# Altium schematic sheet iF11M.SchDoc
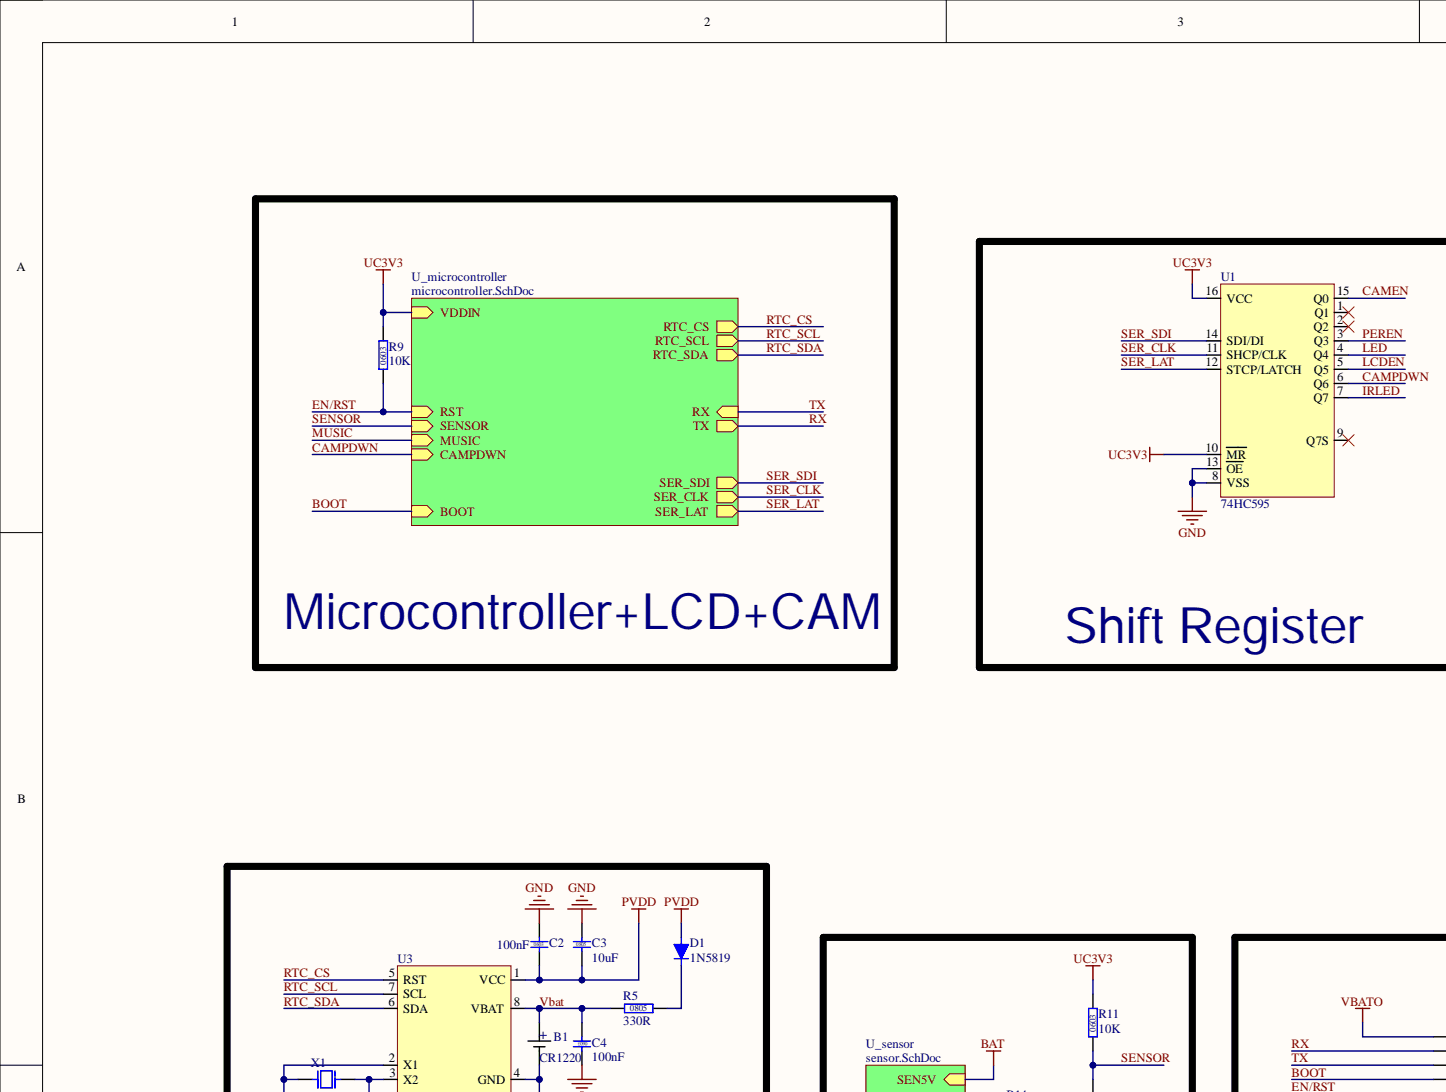
[diagram p0: iF11M.SchDoc, top left]
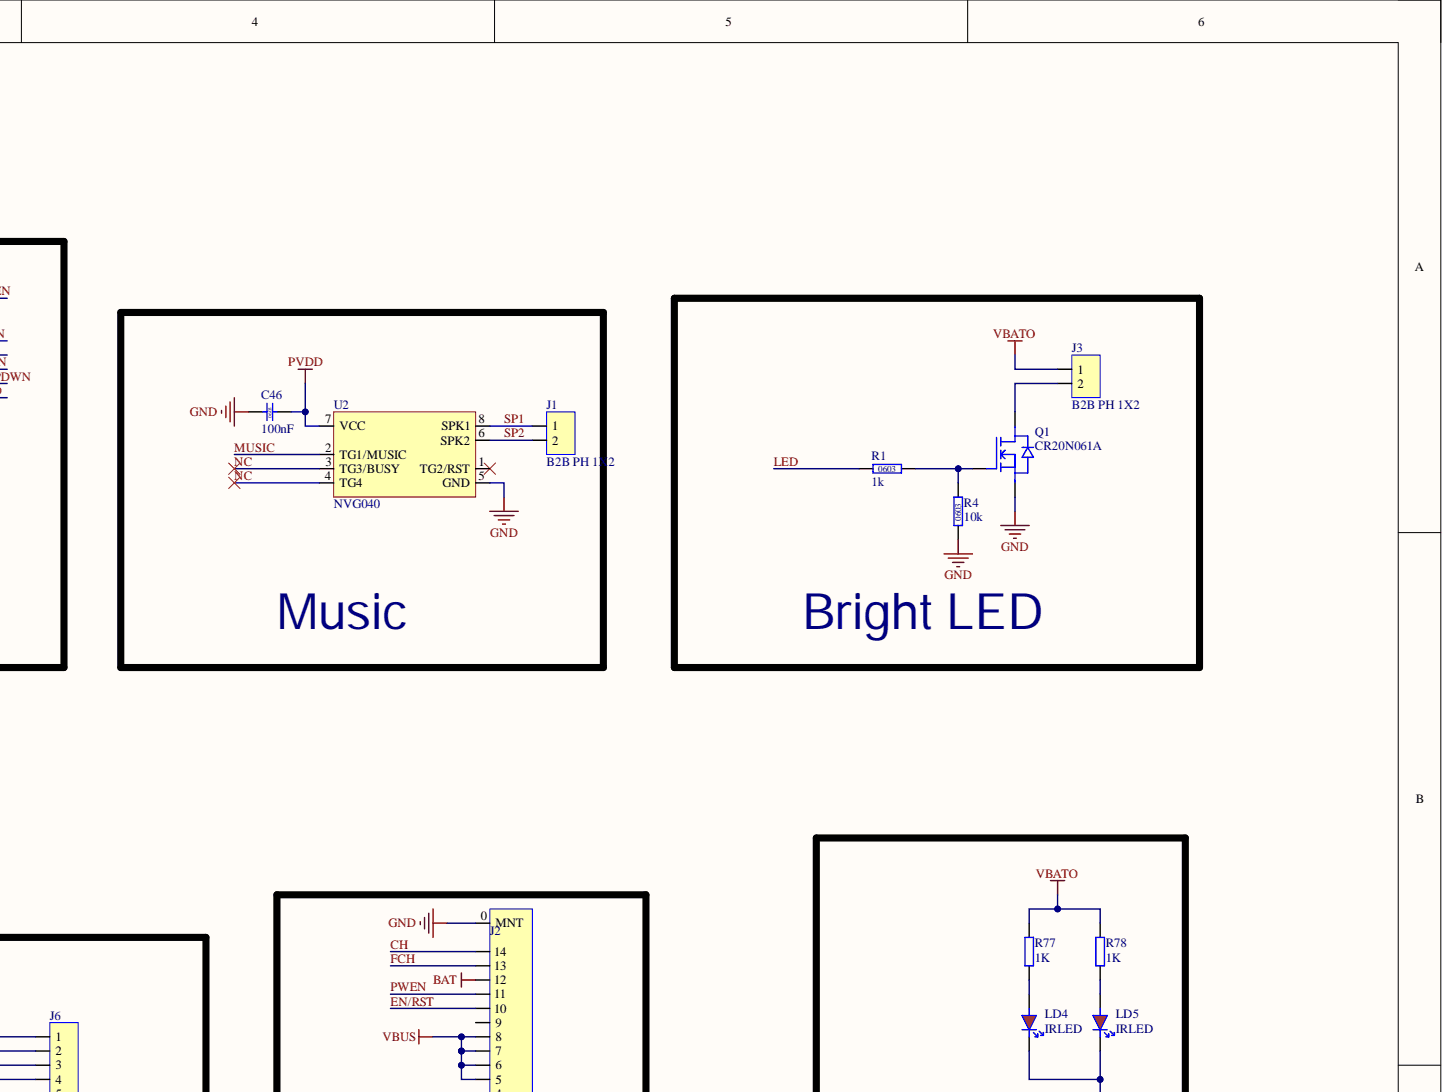
[diagram p1: iF11M.SchDoc, top right]
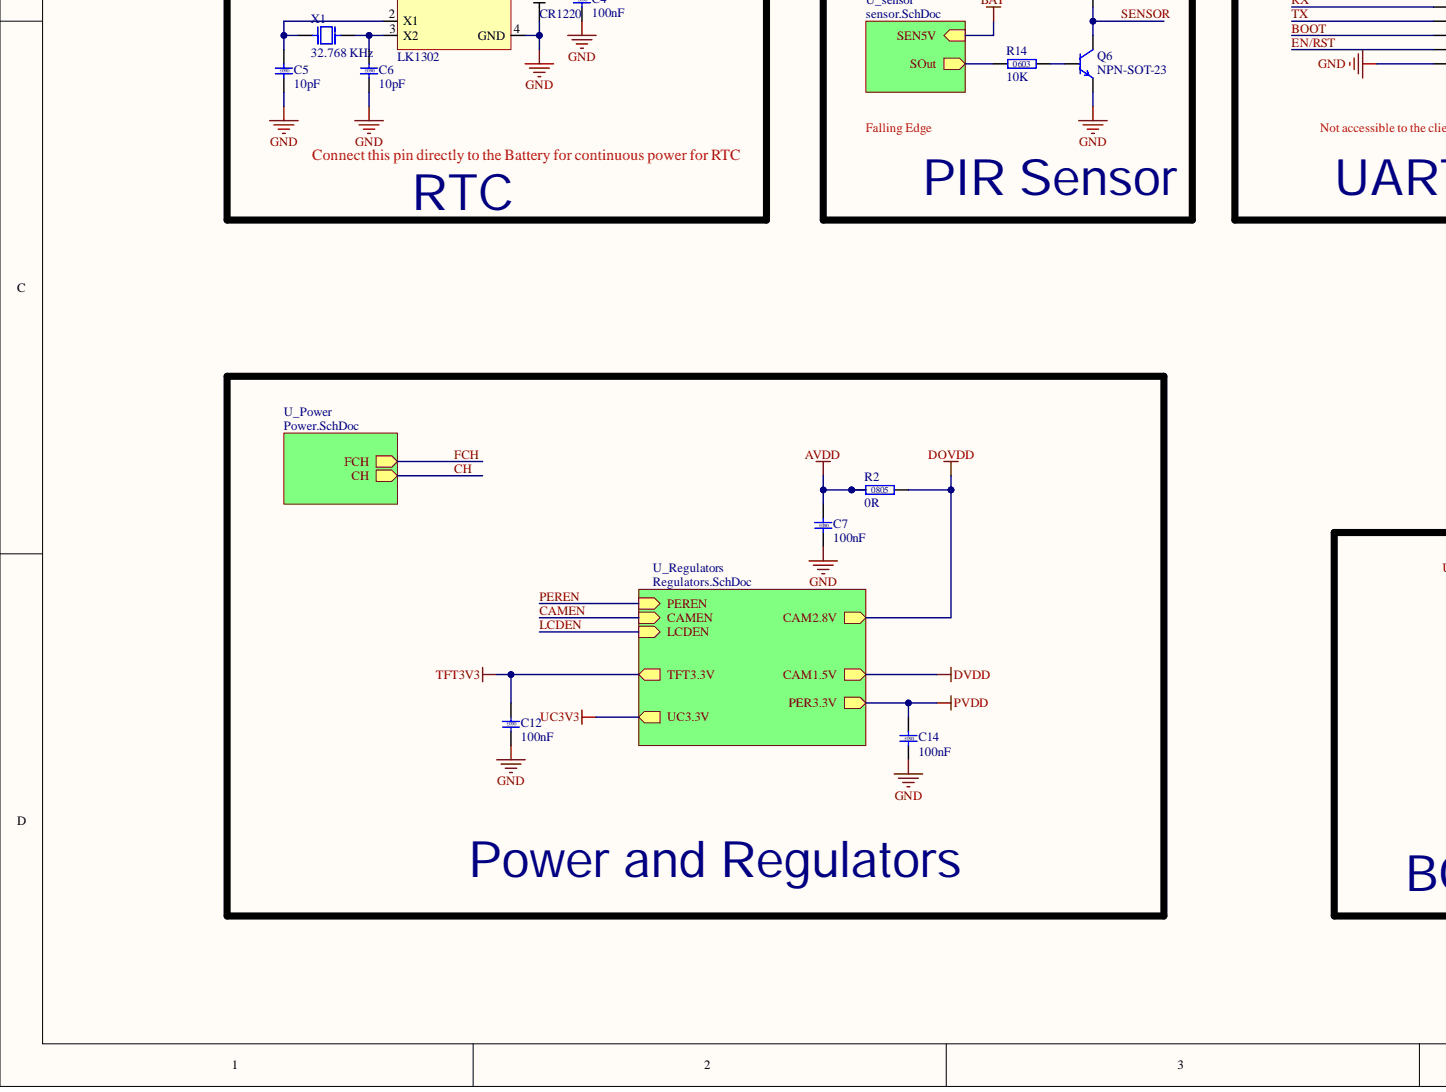
[diagram p2: iF11M.SchDoc, bottom left]
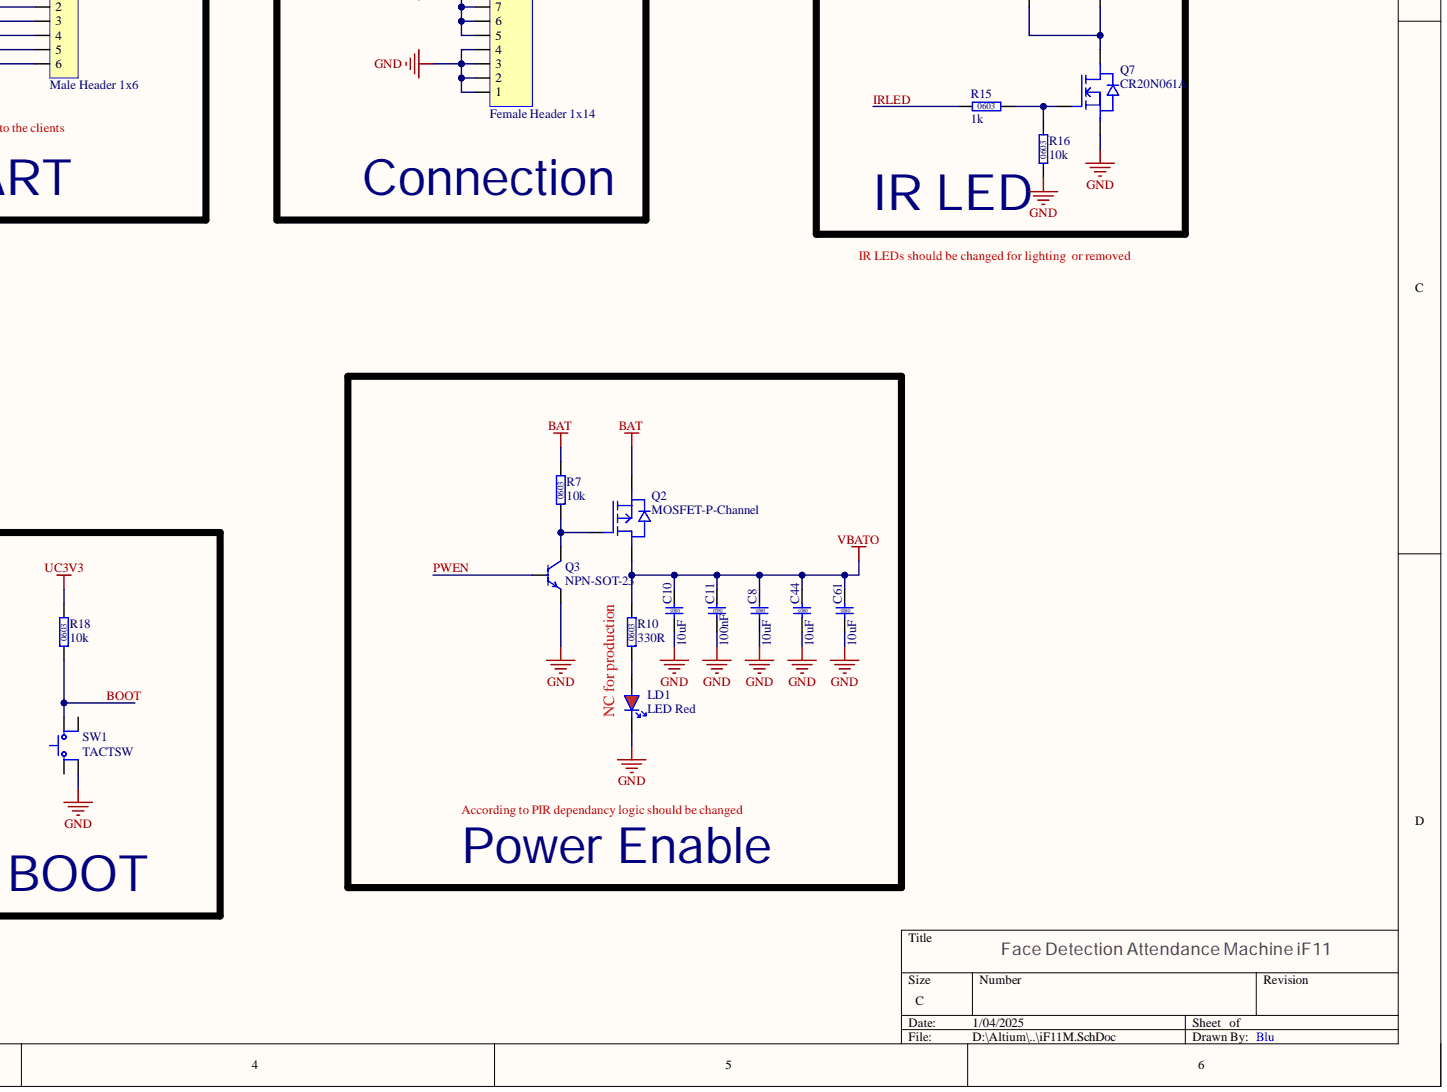
[diagram p3: iF11M.SchDoc, bottom right]

## Parts
B1  CR1220  [CR1220]
C2  100nF  [C0603]
C3  10uF  [C0805]
C4  100nF  [C0603]
C5  10pF  [C0603]
C6  10pF  [C0603]
C7  100nF  [C0603]
C8  10uF  [C0805]
C10  10uF  [C0805]
C11  100nF  [C0603]
C12  100nF  [C0603]
C14  100nF  [C0603]
C44  10uF  [C0805]
C46  100nF  [C0603]
C61  10uF  [C0805]
D1  1N5819  [SOD-323]
J1  B2B PH 1X2  [B2B-PH-2mm]
J2  Female Header 1x14  [FPC14-1mm-SMT]
J3  B2B PH 1X2  [B2B-PH-2mm]
J6  Male Header 1x6  [MHEADER-1x6]
LD1  LED Red  [LED-0603-RED]
LD4  IRLED  [LED 5mm RED Bent]
LD5  IRLED  [LED 5mm RED Bent]
Q1  CR20N061A  [NMOS-SOT23]
Q2  MOSFET-P-Channel  [PMOS-SOT23]
Q3  NPN-SOT-23  [SOT23]
Q6  NPN-SOT-23  [SOT23]
Q7  CR20N061A  [NMOS-SOT23]
R1  1k  [R0603-LD]
R2  0R  [R0805-LD]
R4  10k  [R0603-LD]
R5  330R  [R0805-LD]
R7  10k  [R0603-LD]
R9  10K  [R0603-LD]
R10  330R  [R0603-LD]
R11  10K  [R0603-LD]
R14  10K  [R0603-LD]
R15  1k  [R0603-LD]
R16  10k  [R0603-LD]
R18  10k  [R0603-LD]
R77  1K  [R0805]
R78  1K  [R0805]
SW1  TACTSW  [SMDtactswitch-5x5]
U1  74HC595  [SOIC16]
U2  NVG040  [SOP-8]
U3  LK1302  [SOP-8]
X1  32.768 KHz  [RTC Crystal]

## Nets
AVDD: C7.2 R2.1
BAT: J2.12 Q2.3 R7.2
DOVDD: R2.2
GND: B1.1 C2.1 C3.1 C4.1 C5.1 C6.1 C7.1 C8.1 C10.1 C11.1 C12.1 C14.1 C44.1 C46.1 C61.1 J2.0(MNT) J2.1 J2.2 J2.3 J2.4 J6.6 LD1.2 Q1.3 Q3.2 Q6.2 Q7.3 R4.1 R16.1 SW1.2 U1.8(VSS) U1.13(OE) U2.5(GND) U3.4(GND)
PVDD: C2.2 C3.2 C14.2 C46.2 D1.1 U2.7(VCC) U3.1(VCC)
TFT3V3: C12.2
UC3V3: R9.2 R11.2 R18.2 U1.10(MR) U1.16(VCC)
VBATO: C8.2 C10.2 C11.2 C44.2 C61.2 J3.1 J6.1 Q2.2 R10.2 R77.2 R78.2
VBUS: J2.5 J2.6 J2.7 J2.8
BOOT: J6.4 R18.1 SW1.4
CAMEN: U1.15(Q0)
CAMPDWN: U1.6(Q6)
CH: J2.14
EN/RST: J2.10 J6.5 R9.1
FCH: J2.13
IRLED: R15.1 U1.7(Q7)
LCDEN: U1.5(Q5)
LED: R1.1 U1.4(Q4)
MUSIC: U2.2(TG1/MUSIC)
NC: U2.3(TG3/BUSY) U2.4(TG4)
PEREN: U1.3(Q3)
PWEN: J2.11 Q3.1
RTC_CS: U3.5(RST)
RTC_SCL: U3.7(SCL)
RTC_SDA: U3.6(SDA)
RX: J6.2
SENSOR: Q6.3 R11.1
SER_CLK: U1.11(SHCP/CLK)
SER_LAT: U1.12(STCP/LATCH)
SER_SDI: U1.14(SDI/DI)
SP1: J1.1 U2.8(SPK1)
SP2: J1.2 U2.6(SPK2)
TX: J6.3
Vbat: B1.2 C4.2 R5.1 U3.8(VBAT)
NetC5_2: C5.2 U3.2(X1) X1.2
NetC6_2: C6.2 U3.3(X2) X1.1
NetD1_2: D1.2 R5.2
NetJ2_9: J2.9
NetJ3_2: J3.2 Q1.2
NetLD1_1: LD1.1 R10.1
NetLD4_1: LD4.1 R77.1
NetLD4_2: LD4.2 LD5.2 Q7.2
NetLD5_1: LD5.1 R78.1
NetQ1_1: Q1.1 R1.2 R4.2
NetQ2_1: Q2.1 Q3.3 R7.1
NetQ6_1: Q6.1 R14.2
NetQ7_1: Q7.1 R15.2 R16.2
NetR14_1: R14.1
NetSW1_1: SW1.1
NetSW1_3: SW1.3
NetU1_1: U1.1(Q1)
NetU1_2: U1.2(Q2)
NetU1_9: U1.9(Q7S)
NetU2_1: U2.1(TG2/RST)
DVDD:

## Library data of the parts
C2 C4 C7 C11 C12 C14 C46 = C 0603 100nF 50V: 10% 50V; Manufacturer: FENGHUA; Part No: W-T-CA-X703N100K50VNT; Material Name: 贴片电容0603 100NF/50V 10%; Product Code: WP0002721; Component Kind: Standard; Supplier: 南京南山半导体有限公司; Library Name: Rescap.IntLib
C3 C8 C10 C44 C61 = C 0805 10uF 25V: 10% 25V; Part No: WP0005368; Material Name: 贴片电容0805 10UF/25V 10%; Component Kind: Standard; Product Code: WP0005368; Supplier: 深圳市四叶电子有限公司; Library Name: Rescap.IntLib
C5 C6 = C 0603 10pF 25V: 5% 25V; Part No: W-T-CA-CG03P010J25VNT; Material Name: 贴片电容0603 10PF/25V 5%; Product Code: WP0002897; Component Kind: Standard; Supplier: 南京南山半导体有限公司; Library Name: Rescap.IntLib
D1 = IN4148: Component Kind: Standard; Library Name: Rectifier.IntLib
J1 J3 = B2B-PH-2MM: 2 Pin B2B Connector; Component Kind: Standard; Library Name: Connector.IntLib
J2 = FPC14-1mm: 16 Pin Female Header; Component Kind: Standard; Library Name: Connector.IntLib
J6 = MHeader-1x6: 6 Pin Male Header; Component Kind: Standard; Library Name: Connector.IntLib
Q1 Q7 = MOSFET-N-Channel: Component Kind: Standard; Description: MOSFET-N-Channel; Library Name: Transistor.IntLib
R1 R4 R7 R15 R16 R18 = R 0603 10k: 5%; Manufacturer: 热敏D541V11增加1颗用量; Part No: W-T-RE-RS03K103JT; Material Name: 贴片电阻0603 10K 5%; Product Code: WP0002708; Component Kind: Standard; Supplier: 南京南山半导体有限公司; Library Name: Rescap.IntLib
R2 = R 0805 0R: 1%; Part No: W-T-RE-RS05K0R0JT; Material Name: 贴片电阻0805 0Ω 1%; Product Code: WP0003559; Component Kind: Standard; Supplier: 深圳市四叶电子有限公司; Library Name: Rescap.IntLib
R5 = R 0805 330R: 1%; Part No: W-T-RE-RS05K331JT; Material Name: 贴片电阻0805 330Ω 1%; Product Code: WP0003840; Component Kind: Standard; Supplier: 深圳市四叶电子有限公司; Library Name: Rescap.IntLib
R9 R11 R14 = R 0603 10K: 5%; Manufacturer: 热敏D541V11增加1颗用量; Part No: W-T-RE-RS03K103JT; Material Name: 贴片电阻0603 10K 5%; Product Code: WP0002708; Component Kind: Standard; Supplier: 南京南山半导体有限公司; Library Name: Rescap.IntLib
R10 = R 0603 330R: 5%; Part No: W-T-RE-RS03K331JT; Material Name: 贴片电阻0603 330Ω 5%; Product Code: WP0003776; Component Kind: Standard; Supplier: 南京南山半导体有限公司; Library Name: Rescap.IntLib
R77 R78 = Resistor: Component Kind: Standard; Library Name: Resistor.IntLib; Suppliers: 南京南山半导体有限公司
SW1 = Tactile-smd_1: 2 pin tactile switch round; Component Kind: Standard; Library Name: Switch.IntLib
U1 = 74595: 8bit Serial/Parallel Shift Register; Component Kind: Standard; Library Name: mylib2.SchLib
U3 = LK1302: Trickle-Charge Timekeeping Chip; Component Kind: Standard; Library Name: mylib2.IntLib
X1 = Crystal_RTC: RTC Crystal; Component Kind: Standard; Library Name: Others.IntLib
B1 = CR1220: Component Kind: Standard; Library Name: Others.IntLib
LD1 = LED Red: Component Kind: Standard; Library Name: Optoelectronics.SchLib
LD4 LD5 = IRLED: Component Kind: Standard; Library Name: Optoelectronics.SchLib
Q2 = MOSFET-P-Channel: Component Kind: Standard; Description: MOSFET-P-Channel; Library Name: Transistor.IntLib
Q3 Q6 = NPN-SOT-23: Component Kind: Standard; Library Name: Transistor.IntLib
U2 = NVG040: Component Kind: Standard; Library Name: mylib2.IntLib
